# Altium schematic sheet 10-Launchpad.SchDoc
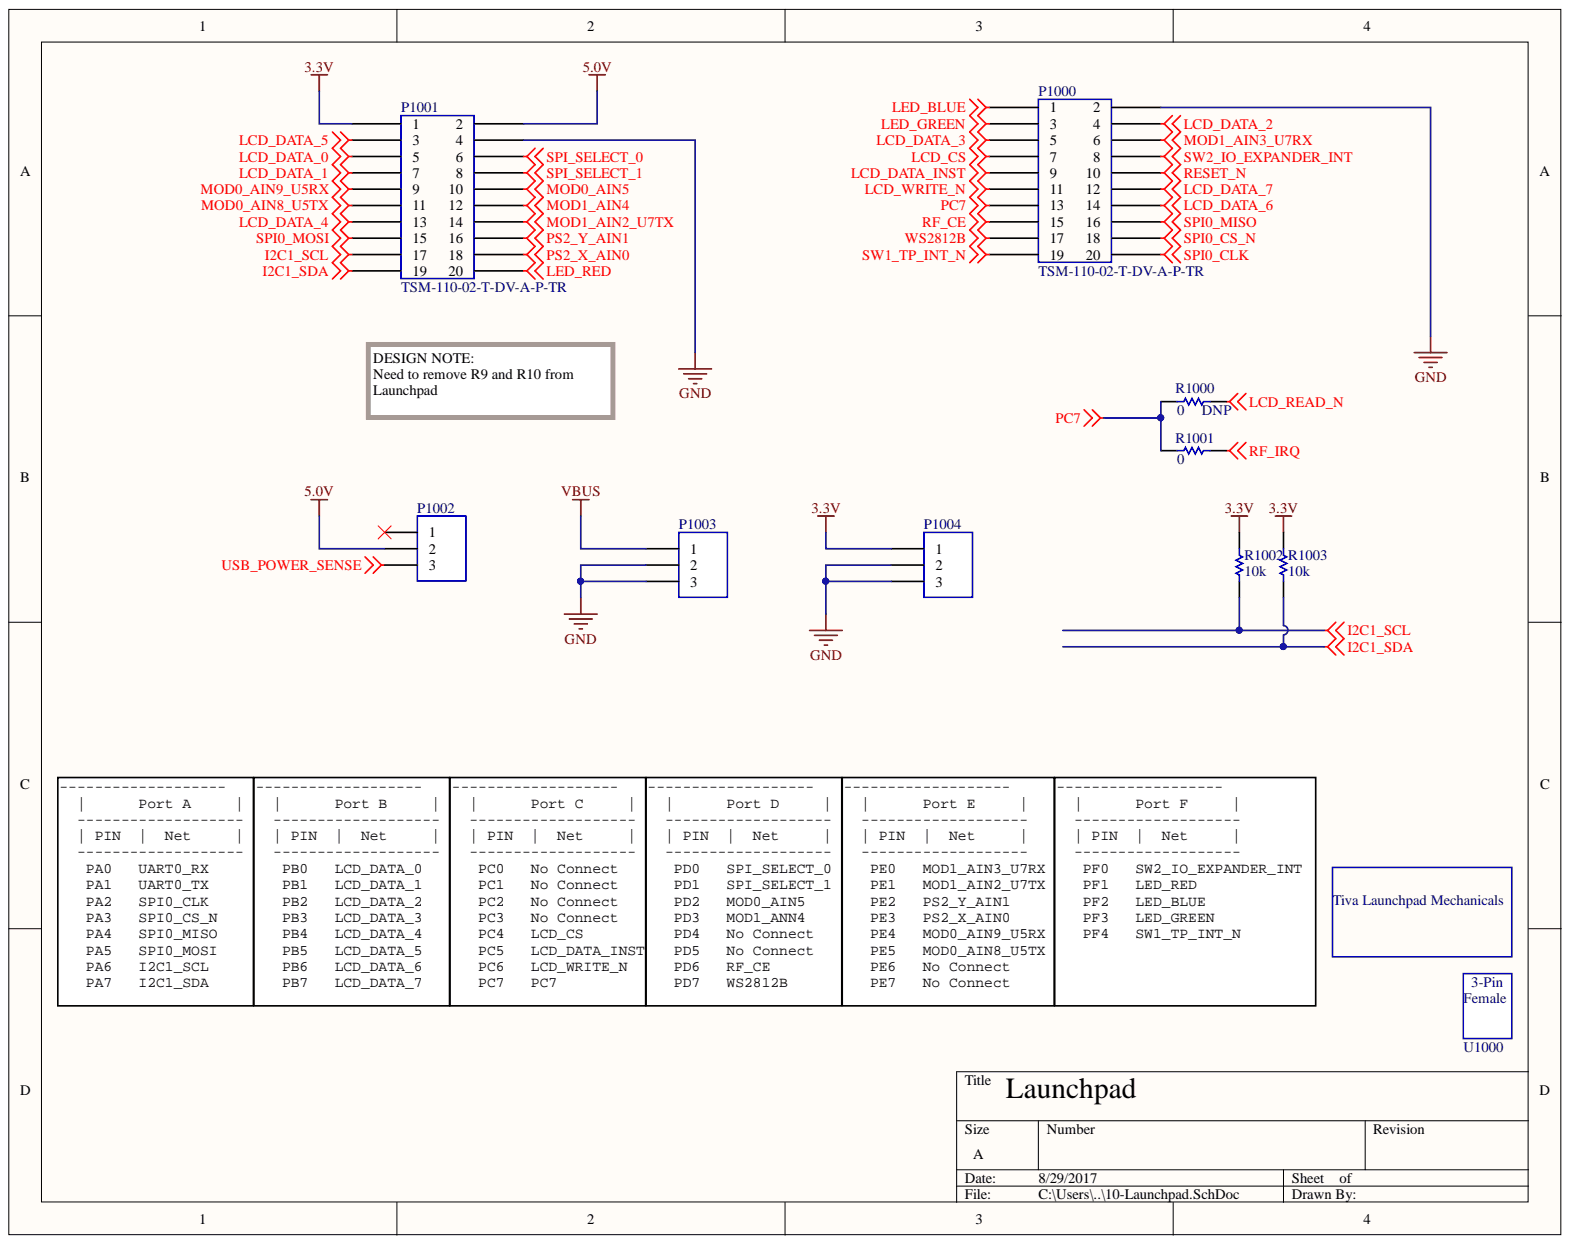
[diagram p0: 10-Launchpad.SchDoc]

## Parts
*1000  Tiva Launchpad Mechanicals  [TivaLaunchPad-Mechanical]  (no BOM)
P1000  Manufacturer Part Number: TSM-110-02-T-DV-A-P-TR  [TMS-110-01-T-DV-A]  (hidden comment: 20 Pin SMD)
P1001  Manufacturer Part Number: TSM-110-02-T-DV-A-P-TR  [TMS-110-01-T-DV-A]  (hidden comment: 20 Pin SMD)
P1002  Header_3  [HDR1X3]  (value not drawn)  (no BOM)
P1003  Header_3  [HDR1X3]  (value not drawn)  (no BOM)
P1004  Header_3  [HDR1X3]  (value not drawn)  (no BOM)
R1000  0  Usage: DNP  [RES_0805]  (no BOM)
R1001  0  [RES_0805]
R1002  10k  [RES_0805]
R1003  10k  [RES_0805]
U1000  TivaLaunchpad_3_Pin_Female  (value not drawn)  (no BOM)

## Nets
3.3V: P1001.1 P1004.1 R1002.2 R1003.2
5.0V: P1001.2 P1002.2
GND: P1000.2 P1001.4 P1003.2 P1003.3 P1004.2 P1004.3
I2C1_SCL: P1001.17 R1002.1
I2C1_SDA: P1001.19 R1003.1
LCD_CS: P1000.7
LCD_DATA_0: P1001.5
LCD_DATA_1: P1001.7
LCD_DATA_2: P1000.4
LCD_DATA_3: P1000.5
LCD_DATA_4: P1001.13
LCD_DATA_5: P1001.3
LCD_DATA_6: P1000.14
LCD_DATA_7: P1000.12
LCD_DATA_INST: P1000.9
LCD_READ_N: R1000.1
LCD_WRITE_N: P1000.11
LED_BLUE: P1000.1
LED_GREEN: P1000.3
LED_RED: P1001.20
MOD0_AIN5: P1001.10
MOD0_AIN8_U5TX: P1001.11
MOD0_AIN9_U5RX: P1001.9
MOD1_AIN2_U7TX: P1001.14
MOD1_AIN3_U7RX: P1000.6
MOD1_AIN4: P1001.12
PC7: P1000.13 R1000.2 R1001.2
PS2_X_AIN0: P1001.18
PS2_Y_AIN1: P1001.16
RESET_N: P1000.10
RF_CE: P1000.15
RF_IRQ: R1001.1
SPI0_CLK: P1000.20
SPI0_CS_N: P1000.18
SPI0_MISO: P1000.16
SPI0_MOSI: P1001.15
SPI_SELECT_0: P1001.6
SPI_SELECT_1: P1001.8
SW1_TP_INT_N: P1000.19
SW2_IO_EXPANDER_INT: P1000.8
USB_POWER_SENSE: P1002.3
VBUS: P1003.1
WS2812B: P1000.17

Not connected: P1002 1

## Library data of the parts
*1000 = TivaLaunchpad-Mechanicals: Library Name: ece353-2016.SchLib
P1000 P1001 = TSM-110-02-T-DV-A: Conn Unshrouded Header HDR 20 POS 2.54mm Solder ST SMD Tube; Component Kind: Standard; Library Name: ece353-2016.SchLib; Supplier 1: Newark; Supplier Part Number 1: 78P2391
P1002-P1004 = Header_3: Header, 3-Pin; Manufacturer: Sullins Connector Solutions; Manufacturer Part Number: PREC003SFAN-RC; Connector Type: Header, Unshrouded, Breakaway; Number of Positions: 3; Number of Positions Loaded: All; Pitch: 0.100" (2.54mm); Category: Connectors, Interconnects; Color: Black; Contact Finish: Tin; Contact Finish Thickness: -; Contact Mating Length: 0.318" (8.08mm); Contact Type: Male Pin; Fastening Type: -; Features: -; Library Name: ece353-2016.SchLib; Mounting Type: Through Hole; Number of Rows: 1; Packaging: Bulk; RoHS: RoHS Compliant; Row Spacing: -; Supplier: Digi-Key; Supplier 1: Digi-Key; Supplier Part Number: S1212EC-03-ND; Supplier Part Number 1: S1212EC-03-ND; Termination: Solder
R1000 R1001 = RES_0805_000.00: RES 0, , 1%, 0.125W, 1/8W 0805; Manufacturer: Panasonic Electronic Components; Manufacturer Part Number: ERJ-6GEY0R00V; Tolerance: Jumper; Category: Resistors; Composition: Thick Film; Features: Automotive AEC-Q200; Height: 0.028" (0.70mm); Library Name: ece353-2016.SchLib; Number of Terminations: 2; Operating Temperature: -55°C ~ 155°C; Package / Case: 0805 (2012 Metric); Packaging: Cut Tape (CT); Power (Watts): 0.125W, 1/8W; Resistance: 0; RoHS: RoHS Compliant; Size / Dimension: 0.079" L x 0.049" W (2.00mm x 1.25mm); Supplier: Digi-Key; Supplier 1: Digi-Key; Supplier Device Package: 0805; Supplier Part Number: P0.0ACT-ND; Supplier Part Number 1: P0.0ACT-ND; Temperature Coefficient: -; Value: 0
  R1001: Component Kind: Standard
R1002 R1003 = RES_0805_K_010.00: RES 10K 1/10W 1% 0805 SMD; Manufacturer: Panasonic Electronic Components; Manufacturer Part Number: ERJ-6ENF1002V; Power (Watts): 0.125W, 1/8W; Temperature Coefficient: ±100ppm/°C; Category: Resistors; Component Kind: Standard; Composition: Thick Film; Features: Automotive AEC-Q200; Height: 0.028" (0.70mm); Library Name: ece353-2016.SchLib; Number of Terminations: 2; Operating Temperature: -55°C ~ 155°C; Package / Case: 0805 (2012 Metric); Packaging: Cut Tape (CT); Resistance: 10k; RoHS: RoHS Compliant; Size / Dimension: 0.079" L x 0.049" W (2.00mm x 1.25mm); Supplier: Digi-Key; Supplier 1: Digi-Key; Supplier Device Package: 0805; Supplier Part Number: P10.0KCCT-ND; Supplier Part Number 1: P10.0KCCT-ND; Tolerance: ±1%; Value: 10k
U1000 = TivaLaunchpad_3_Pin_Female: Socket, 3-Pin; Manufacturer: Sullins Connector Solutions; Manufacturer Part Number: PPPC031LFBN-RC; Connector Type: Header; Number of Positions: 3; Number of Positions Loaded: All; Pitch: 0.100" (2.54mm); Usage: DNP; Category: Connectors, Interconnects; Color: Black; Contact Finish: Gold; Contact Finish Thickness: Flash; Contact Type: Female Socket; Fastening Type: -; Features: -; Library Name: ece353-2016.SchLib; Mounting Type: Through Hole; Number of Rows: 1; Packaging: Bulk; RoHS: RoHS Compliant; Row Spacing: -; Supplier: Digi-Key; Supplier 1: Digi-Key; Supplier Part Number: S7036-ND; Supplier Part Number 1: S7036-ND; Termination: Solder
